# Altium schematic sheet 底板原理图2.SchDoc
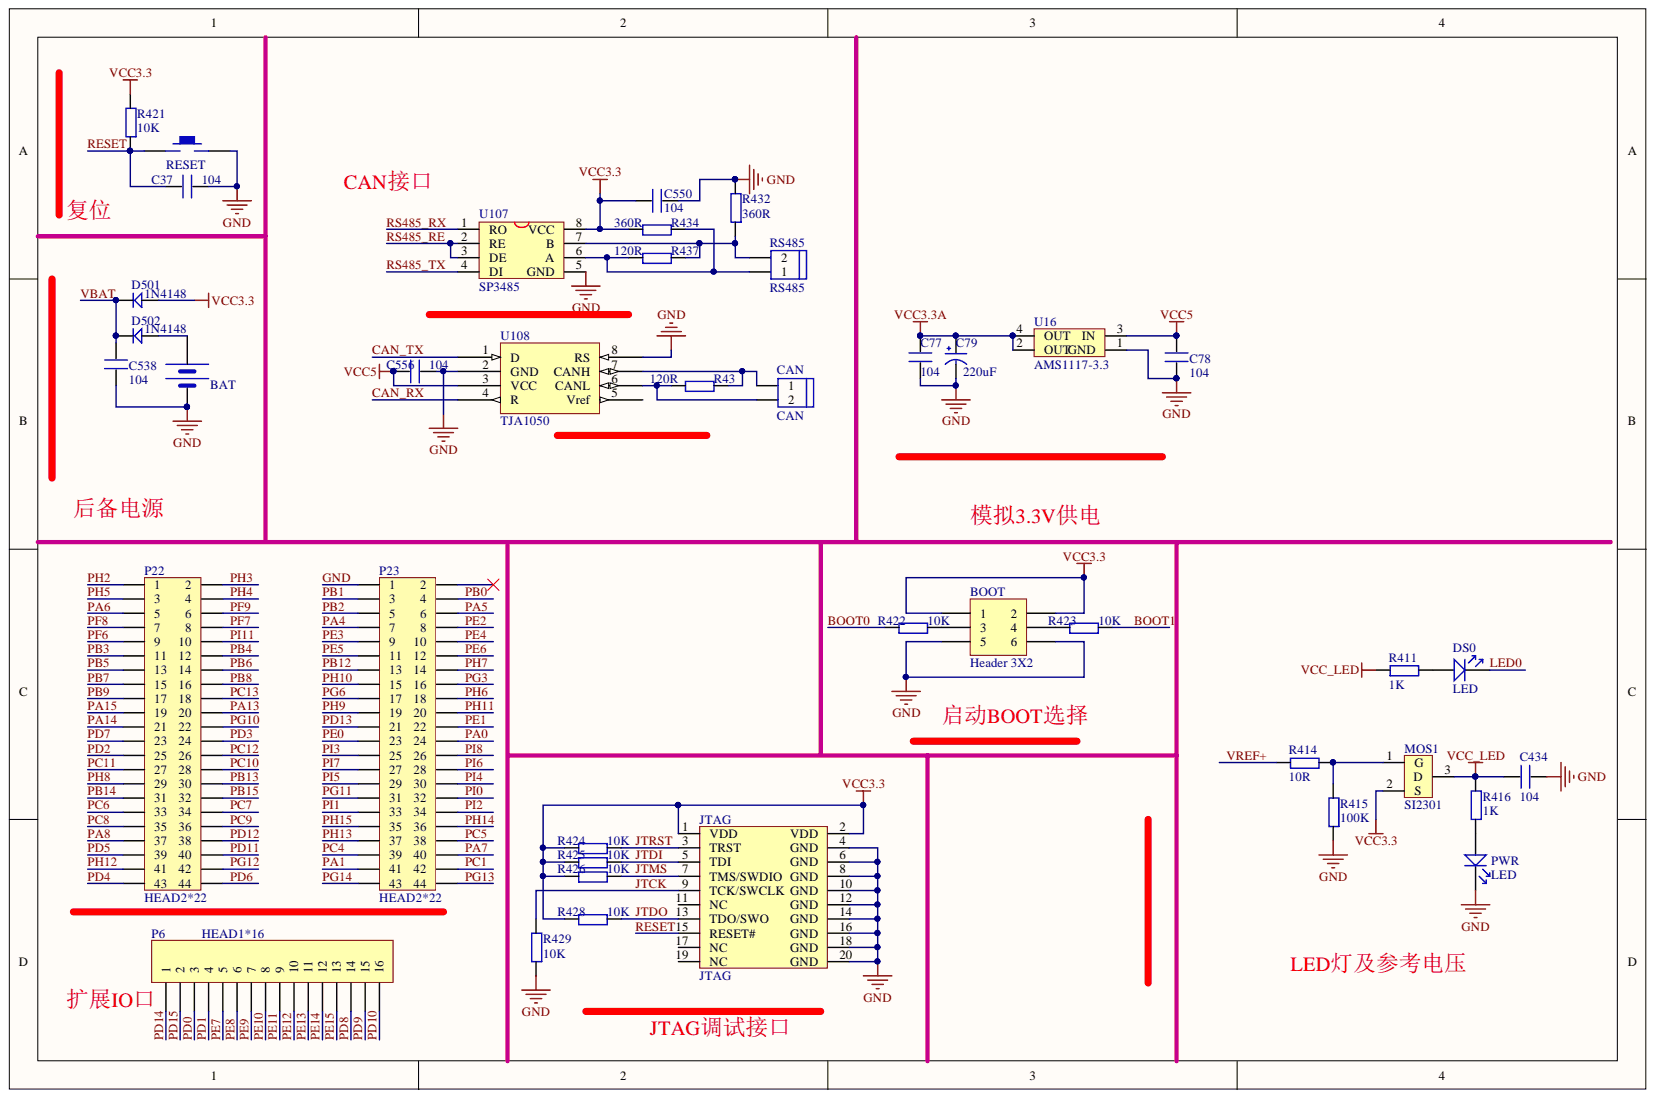
[diagram p0: 底板原理图2.SchDoc]

## Parts
BAT  BATT  [BATM]  (value not drawn)
BOOT  Header 3X2  [HDR2X3]
C37  104  [0603P]
C77  104  [0603P]
C78  104  [0603P]
C79  220uF  [CC6.56.5]
C434  104  [0402]
C538  104  [0603P]
C550  104  [0603P]
C556  104  [0603P]
CAN  CAN  [HEAD2L-0.8]
D501  1N4148  [DIODE_SOP]
D502  1N4148  [DIODE_SOP]
DS0  LED  [SMDLED-0603]
JTAG  JTAG  [JTAG_20]
MOS1  SI2301  [SO-G3/C2.5]
P6  HEAD1*16  [HDR1X16]
P22  HEAD2*22  [HEAD2*22]
P23  HEAD2*22  [HEAD2*22]
PWR  LED  [SMDLED-0603]
R43  120R  [0603P]
R411  1K  [0402]
R414  10R  [0402]
R415  100K  [0402]
R416  1K  [0402]
R421  10K  [0603P]
R422  10K  [0603P]
R423  10K  [0603P]
R424  10K  [0603P]
R425  10K  [0603P]
R426  10K  [0603P]
R428  10K  [0603P]
R429  10K  [0603P]
R432  360R  [0603P]
R434  360R  [0603P]
R437  120R  [0603P]
RESET  KEY_M  [KEY_M]  (value not drawn)
RS485  RS485  [HEAD2L-0.8]
U16  AMS1117-3.3  [AMS1117]
U107  SP3485  [SOP-8]
U108  TJA1050  [SOP-8]

## Nets
VCC3.3: BOOT.1 BOOT.2 C550.2 D501.1 JTAG.1(VDD) JTAG.2(VDD) MOS1.2 R421.2 R424.1 R425.1 R426.1 R428.1 R434.1 U107.8(VCC)
VCC3.3A: C77.2 C79.1 U16.2(OUT) U16.4(OUT)
VCC5: C78.2 C556.1 U16.3(IN) U108.3(VCC)
VCC_LED: C434.2 MOS1.3 R411.1 R416.2
BOOT0: R422.1
BOOT1: R423.2
CAN_RX: U108.4(R)
CAN_TX: U108.1(D)
GND: BAT.2 BOOT.5 BOOT.6 C37.2 C77.1 C78.1 C79.2 C434.1 C538.1 C550.1 C556.2 JTAG.4(GND) JTAG.6(GND) JTAG.8(GND) JTAG.10(GND) JTAG.12(GND) JTAG.14(GND) JTAG.16(GND) JTAG.18(GND) JTAG.20(GND) P23.1 PWR.2 R415.1 R429.1 R432.2 RESET.2 U16.1(GND) U107.5(GND) U108.2(GND) U108.8(RS)
JTCK: JTAG.9(TCK/SWCLK) R429.2
JTDI: JTAG.5(TDI) R425.2
JTDO: JTAG.13(TDO/SWO) R428.2
JTMS: JTAG.7(TMS/SWDIO) R426.2
JTRST: JTAG.3(TRST) R424.2
LED0: DS0.2
PA0: P23.24
PA1: P23.41
PA4: P23.7
PA5: P23.6
PA6: P22.5
PA7: P23.40
PA8: P22.37
PA13: P22.20
PA14: P22.21
PA15: P22.19
PB0: P23.4
PB1: P23.3
PB2: P23.5
PB3: P22.11
PB4: P22.12
PB5: P22.13
PB6: P22.14
PB7: P22.15
PB8: P22.16
PB9: P22.17
PB12: P23.13
PB13: P22.30
PB14: P22.31
PB15: P22.32
PC1: P23.42
PC4: P23.39
PC5: P23.38
PC6: P22.33
PC7: P22.34
PC8: P22.35
PC9: P22.36
PC10: P22.28
PC11: P22.27
PC12: P22.26
PC13: P22.18
PD0: P6.3
PD1: P6.4
PD2: P22.25
PD3: P22.24
PD4: P22.43
PD5: P22.39
PD6: P22.44
PD7: P22.23
PD8: P6.14
PD9: P6.15
PD10: P6.16
PD11: P22.40
PD12: P22.38
PD13: P23.21
PD14: P6.1
PD15: P6.2
PE0: P23.23
PE1: P23.22
PE2: P23.8
PE3: P23.9
PE4: P23.10
PE5: P23.11
PE6: P23.12
PE7: P6.5
PE8: P6.6
PE9: P6.7
PE10: P6.8
PE11: P6.9
PE12: P6.10
PE13: P6.11
PE14: P6.12
PE15: P6.13
PF6: P22.9
PF7: P22.8
PF8: P22.7
PF9: P22.6
PG3: P23.16
PG6: P23.17
PG10: P22.22
PG11: P23.31
PG12: P22.42
PG13: P23.44
PG14: P23.43
PH2: P22.1
PH3: P22.2
PH4: P22.4
PH5: P22.3
PH6: P23.18
PH7: P23.14
PH8: P22.29
PH9: P23.19
PH10: P23.15
PH11: P23.20
PH12: P22.41
PH13: P23.37
PH14: P23.36
PH15: P23.35
PI0: P23.32
PI1: P23.33
PI2: P23.34
PI3: P23.25
PI4: P23.30
PI5: P23.29
PI6: P23.28
PI7: P23.27
PI8: P23.26
PI11: P22.10
RESET: C37.1 JTAG.15(RESET#) R421.1 RESET.1
RS485_RE: U107.2(RE) U107.3(DE)
RS485_RX: U107.1(RO)
RS485_TX: U107.4(DI)
VBAT: C538.2 D501.2 D502.2
VREF+: R414.1
NetBAT_1: BAT.1 D502.1
NetBOOT_3: BOOT.3 R422.2
NetBOOT_4: BOOT.4 R423.1
NetCAN_1: CAN.1 R43.2 U108.7(CANH)
NetCAN_2: CAN.2 R43.1 U108.6(CANL)
NetDS0_1: DS0.1 R411.2
NetMOS1_1: MOS1.1 R414.2 R415.2
NetPWR_1: PWR.1 R416.1
NetR432_1: R432.1 R437.2 RS485.2 U107.7(B)
NetR434_2: R434.2 R437.1 RS485.1 U107.6(A)

Not connected: JTAG 11(NC) 17(NC) 19(NC); P23 2; U108 5(Vref)

## Library data of the parts
BAT = BAT: Component Kind: Standard; Library Name: STM32F429_F746.SCHLIB
BOOT = Header 3X2: Header, 3-Pin, Dual row; Component Kind: Standard; LatestRevisionDate: 17-Jul-2002; LatestRevisionNote: Re-released for DXP Platform.; Library Name: STM32F429_F746.SCHLIB; Publisher: Altium Limited
C37 C77 C78 C434 C538 C550 C556 = C: Component Kind: Standard
  C37 C77 C78 C538 C550 C556: Library Name: STM32F429_F746.SCHLIB
  C434: Library Name: STM32F429_CORE.SCHLIB
C79 = CAP: Component Kind: Standard; Library Name: STM32F429_F746.SCHLIB
CAN RS485 = HEAD2: Component Kind: Standard; Library Name: STM32F429_F746.SCHLIB
D501 D502 = D: Component Kind: Standard; Library Name: STM32F429_F746.SCHLIB
MOS1 = MOS-P: IRLML6401/SI2301; Component Kind: Standard; Library Name: STM32F429_CORE.SCHLIB
P6 = Header 16: Header, 16-Pin; Component Kind: Standard; LatestRevisionDate: 17-Jul-2002; LatestRevisionNote: Re-released for DXP Platform.; Library Name: STM32F429_F746.SCHLIB; Signal Integrity: Connector; Publisher: Altium Limited
R43 R411 R414-R416 R421-R426 R428 R429 R432 R434 R437 = R: Component Kind: Standard
  R43 R421-R426 R428 R429 R432 R434 R437: Library Name: STM32F429_F746.SCHLIB
  R411 R414-R416: Library Name: STM32F429_CORE.SCHLIB
U16 = AMS1117: Component Kind: Standard; Library Name: STM32F429_F746.SCHLIB
U107 = MAX3485: Component Kind: Standard; Library Name: STM32F429_F746.SCHLIB
U108 = SN65HVD230D: Component Kind: Standard; Library Name: STM32F429_F746.SCHLIB
DS0 PWR = LED: Component Kind: Standard; Library Name: STM32F429_CORE.SCHLIB
JTAG = JTAG: Component Kind: Standard; Library Name: STM32F429_F746.SCHLIB
P22 P23 = HEAD2*22: Component Kind: Standard; Library Name: STM32F429_F746.SCHLIB
RESET = KEY_M: Component Kind: Standard; Library Name: STM32F429_F746.SCHLIB
